# Altium schematic sheet Digital.SchDoc(Digital)
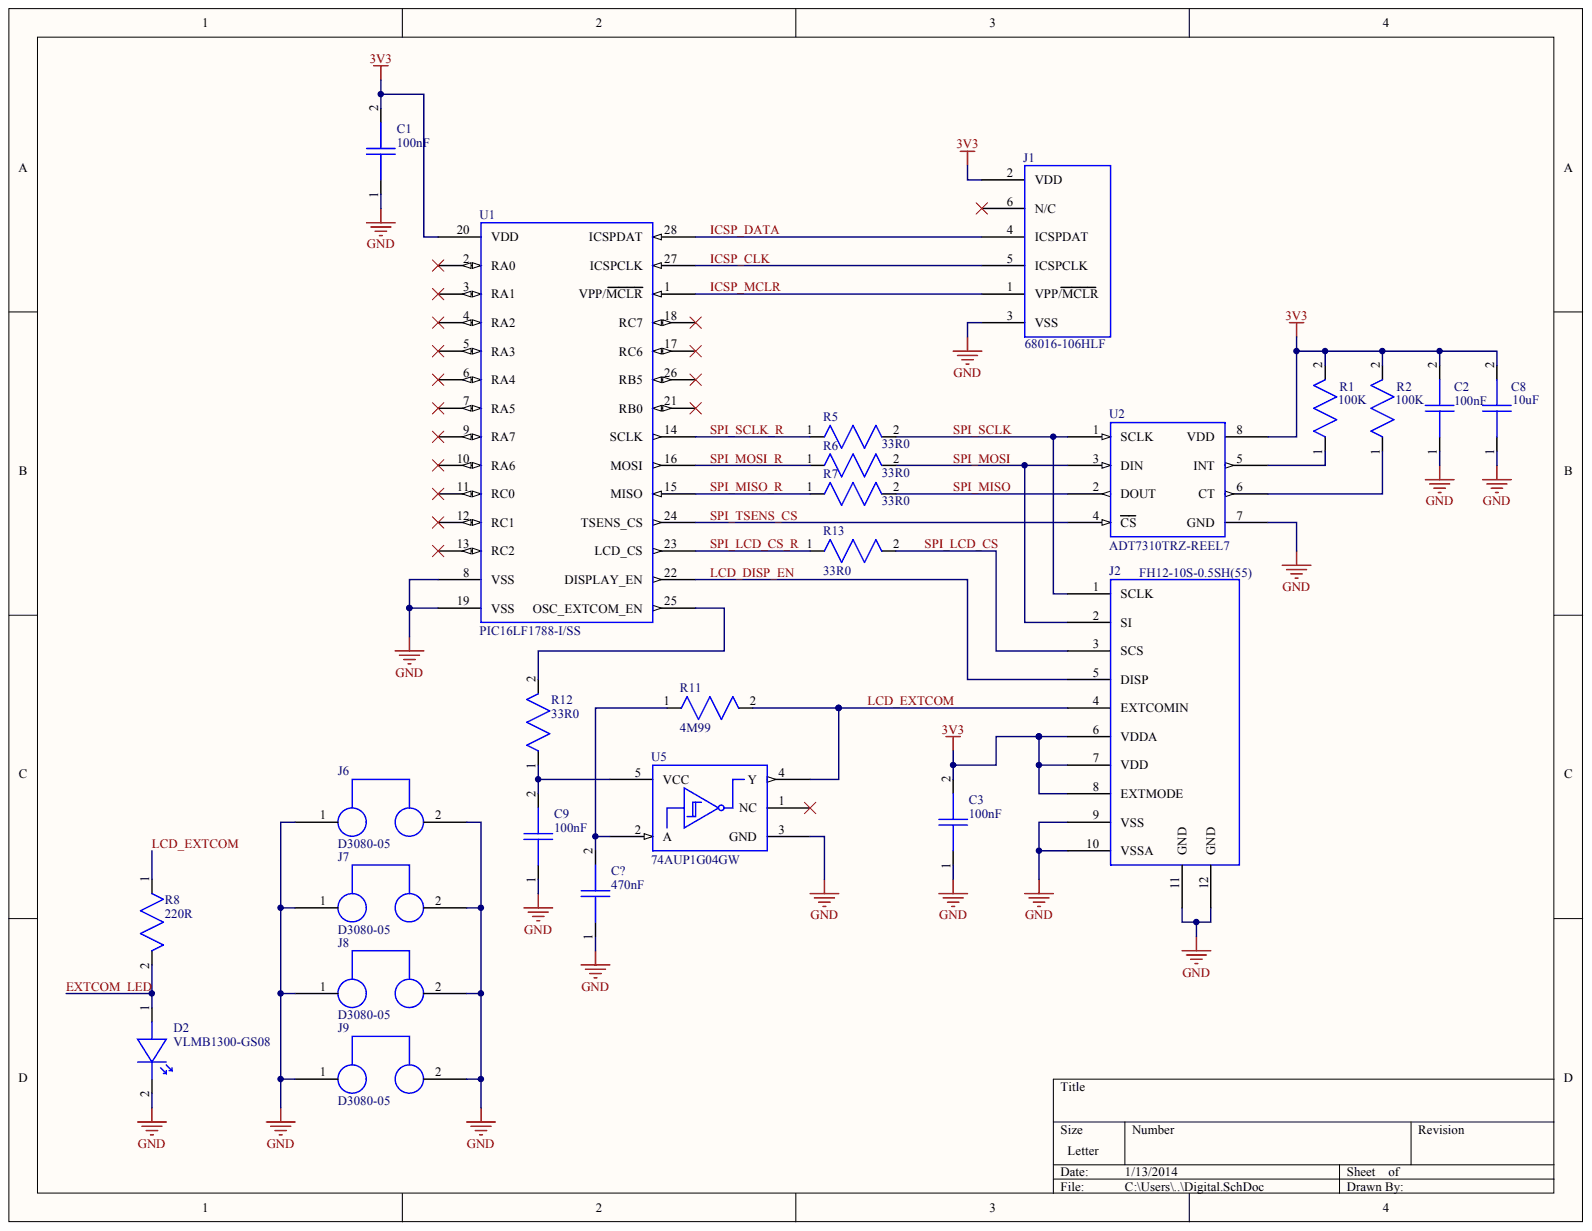
[diagram p0: Digital.SchDoc]

## Parts
C1  Inductance: 100nF  (hidden comment: CAP_0603_100nF)
C2  Inductance: 100nF  (hidden comment: CAP_0603_100nF)
C3  Inductance: 100nF  (hidden comment: CAP_0603_100nF)
C8  Inductance: 10uF  (hidden comment: CAP_0603_10u)
C9  Inductance: 100nF  (hidden comment: CAP_0603_100nF)
C?  Inductance: 470nF  (hidden comment: CAP_0603_470nF)
D2  Part Number: VLMB1300-GS08  (hidden comment: LED_BLUE)
J1  Part Number: 68016-106HLF  (hidden comment: CONN_ICSP_HEADER)
J2  Part Number: FH12-10S-0.5SH(55)  (hidden comment: CONN_10POS_HIROSE)
J6  Part Number: D3080-05  (hidden comment: SHORT_D3080-05)
J7  Part Number: D3080-05  (hidden comment: SHORT_D3080-05)
J8  Part Number: D3080-05  (hidden comment: SHORT_D3080-05)
J9  Part Number: D3080-05  (hidden comment: SHORT_D3080-05)
R1  Resistance: 100K  (hidden comment: RES_0603_100K)
R2  Resistance: 100K  (hidden comment: RES_0603_100K)
R5  Resistance: 33R0  (hidden comment: RES_0603_33R)
R6  Resistance: 33R0  (hidden comment: RES_0603_33R)
R7  Resistance: 33R0  (hidden comment: RES_0603_33R)
R8  Resistance: 220R  (hidden comment: RES_0603_220R)
R11  Resistance: 4M99  (hidden comment: RES_0603_4M99)
R12  Resistance: 33R0  (hidden comment: RES_0603_33R)
R13  Resistance: 33R0  (hidden comment: RES_0603_33R)
U1  PIC16LF1788  Part Number: PIC16LF1788-I/SS
U2  ADT7310  Part Number: ADT7310TRZ-REEL7
U5  74AUP1G04  Part Number: 74AUP1G04GW

## Nets
3V3: C1.2 C2.2 C3.2 C8.2 J1.2(VDD) J2.6(VDDA) J2.7(VDD) J2.8(EXTMODE) R1.2 R2.2 U1.20(VDD) U2.8(VDD)
GND: C1.1 C2.1 C3.1 C8.1 C9.1 C?.1 D2.2 J1.3(VSS) J2.9(VSS) J2.10(VSSA) J2.11(GND) J2.12(GND) J6.1 J6.2 J7.1 J7.2 J8.1 J8.2 J9.1 J9.2 U1.8(VSS) U1.19(VSS) U2.7(GND) U5.3(GND)
EXTCOM_LED: D2.1 R8.2
ICSP_CLK: J1.5(ICSPCLK) U1.27(ICSPCLK)
ICSP_DATA: J1.4(ICSPDAT) U1.28(ICSPDAT)
ICSP_MCLR: J1.1(VPP/MCLR) U1.1(VPP/MCLR)
LCD_DISP_EN: J2.5(DISP) U1.22(DISPLAY_EN)
LCD_EXTCOM: J2.4(EXTCOMIN) R8.1 R11.2 U5.4(Y)
SPI_LCD_CS: J2.3(SCS) R13.2
SPI_LCD_CS_R: R13.1 U1.23(LCD_CS)
SPI_MISO: R7.2 U2.2(DOUT)
SPI_MISO_R: R7.1 U1.15(MISO)
SPI_MOSI: J2.2(SI) R6.2 U2.3(DIN)
SPI_MOSI_R: R6.1 U1.16(MOSI)
SPI_SCLK: J2.1(SCLK) R5.2 U2.1(SCLK)
SPI_SCLK_R: R5.1 U1.14(SCLK)
SPI_TSENS_CS: U1.24(TSENS_CS) U2.4(CS)
NetC9_2: C9.2 R12.1 U5.5(VCC)
NetC?_2: C?.2 R11.1 U5.2(A)
NetR1_1: R1.1 U2.5(INT)
NetR2_1: R2.1 U2.6(CT)
NetR12_2: R12.2 U1.25(OSC_EXTCOM_EN)

Not connected: J1 6(N/C); U1 2(RA0) 3(RA1) 4(RA2) 5(RA3) 6(RA4) 7(RA5) 9(RA7) 10(RA6) 11(RC0) 12(RC1) 13(RC2) 17(RC6) 18(RC7) 21(RB0) 26(RB5); U5 1(NC)

## Library data of the parts
C1-C3 C9 = (no symbol name): Manufacturer: Vishay; Part Number: VJ0603Y104JXJPW1BC
C8 = (no symbol name): Manufacturer: TDK; Part Number: C1608X5R1A106M080AC
C? = (no symbol name): Manufacturer: Kemet; Part Number: C0603C472J5RACTU
D2 = (no symbol name): Manufacturer: Vishay; Colour: Blue
J1 = (no symbol name): Manufacturer: FCI
J2 = (no symbol name): Manufacturer: Hirose
J6-J9 = (no symbol name): Manufacturer: Harwin
R1 R2 = (no symbol name): Manufacturer: KOA Speer; Part Number: RK73H1JTTD1003F
R5-R7 R12 R13 = (no symbol name): Manufacturer: RR0816Q-330-D; Part Number: RK73H1JTTD2200F
R8 = (no symbol name): Manufacturer: KOA Speer; Part Number: RK73H1JTTD2200F
R11 = (no symbol name): Manufacturer: Vishay; Part Number: CRCW06034M99FKEA
U1 = (no symbol name): Manufacturer: Microchip; Package: SSOP-28
U2 = (no symbol name): Manufacturer: Analog Devices; Package: SOIC-8
U5 = (no symbol name): Manufacturer: NXP; Package: SOT353-5
